# Altium schematic sheet Sheet1.SchDoc
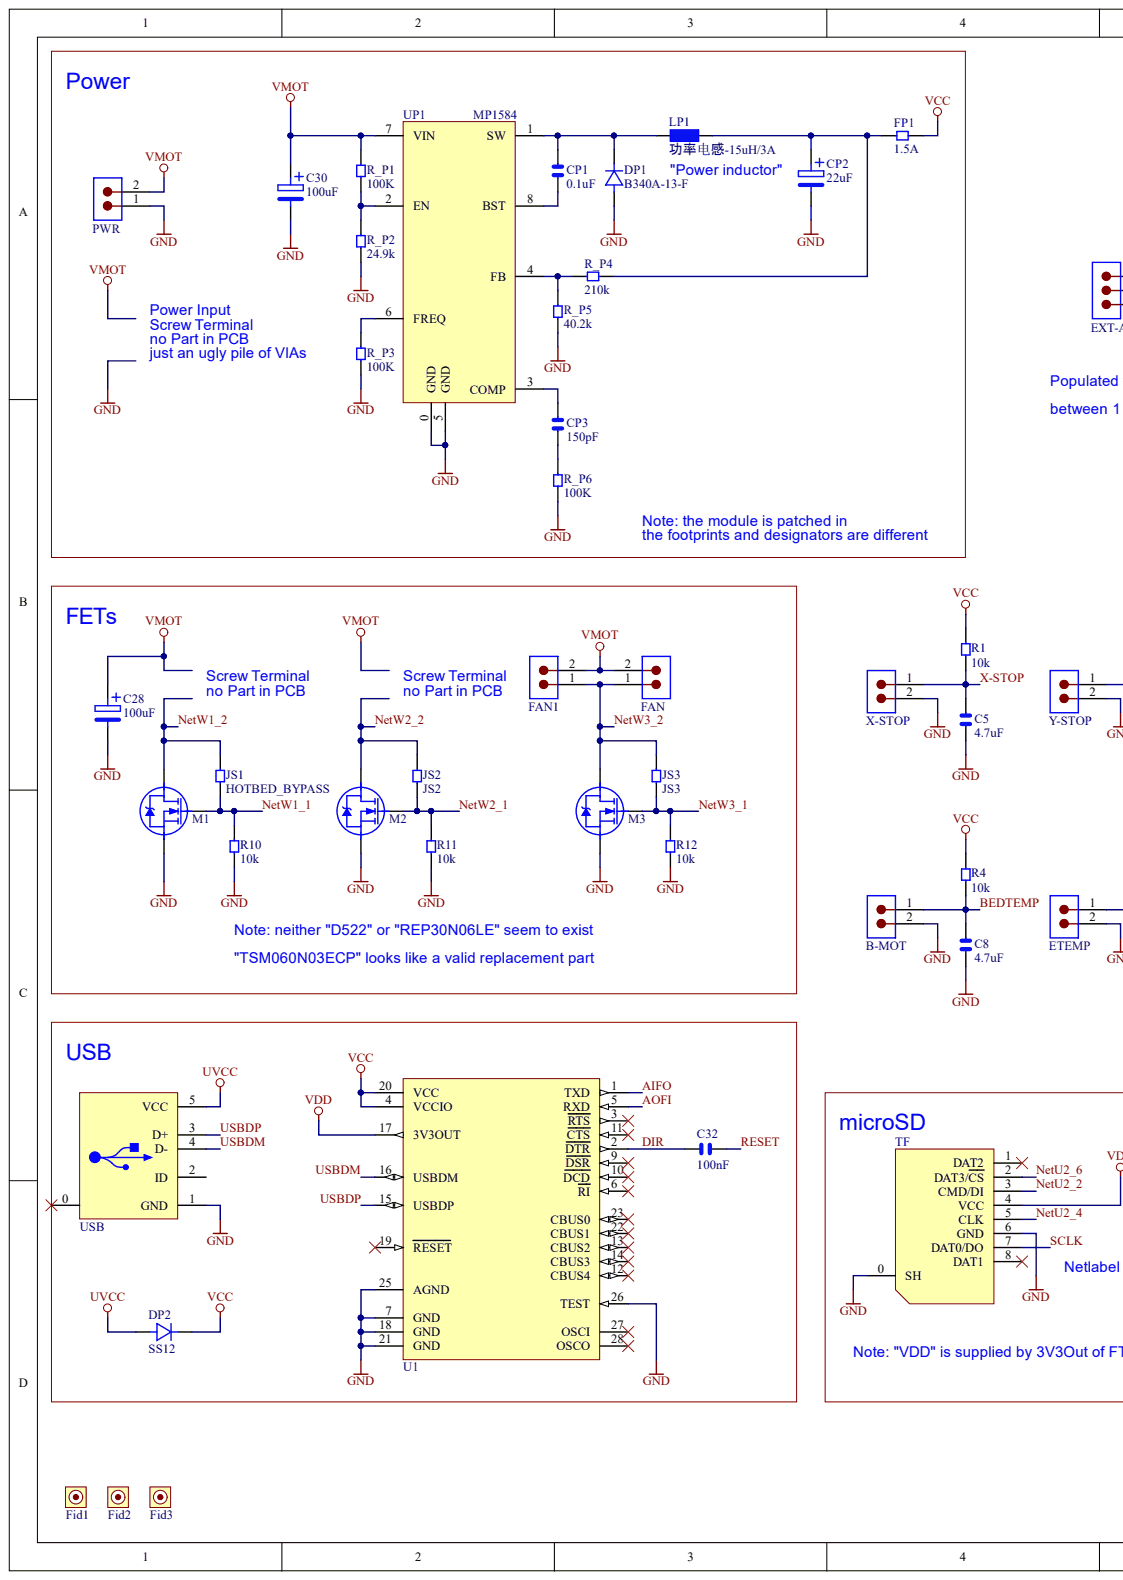
[diagram p0: Sheet1.SchDoc, left]
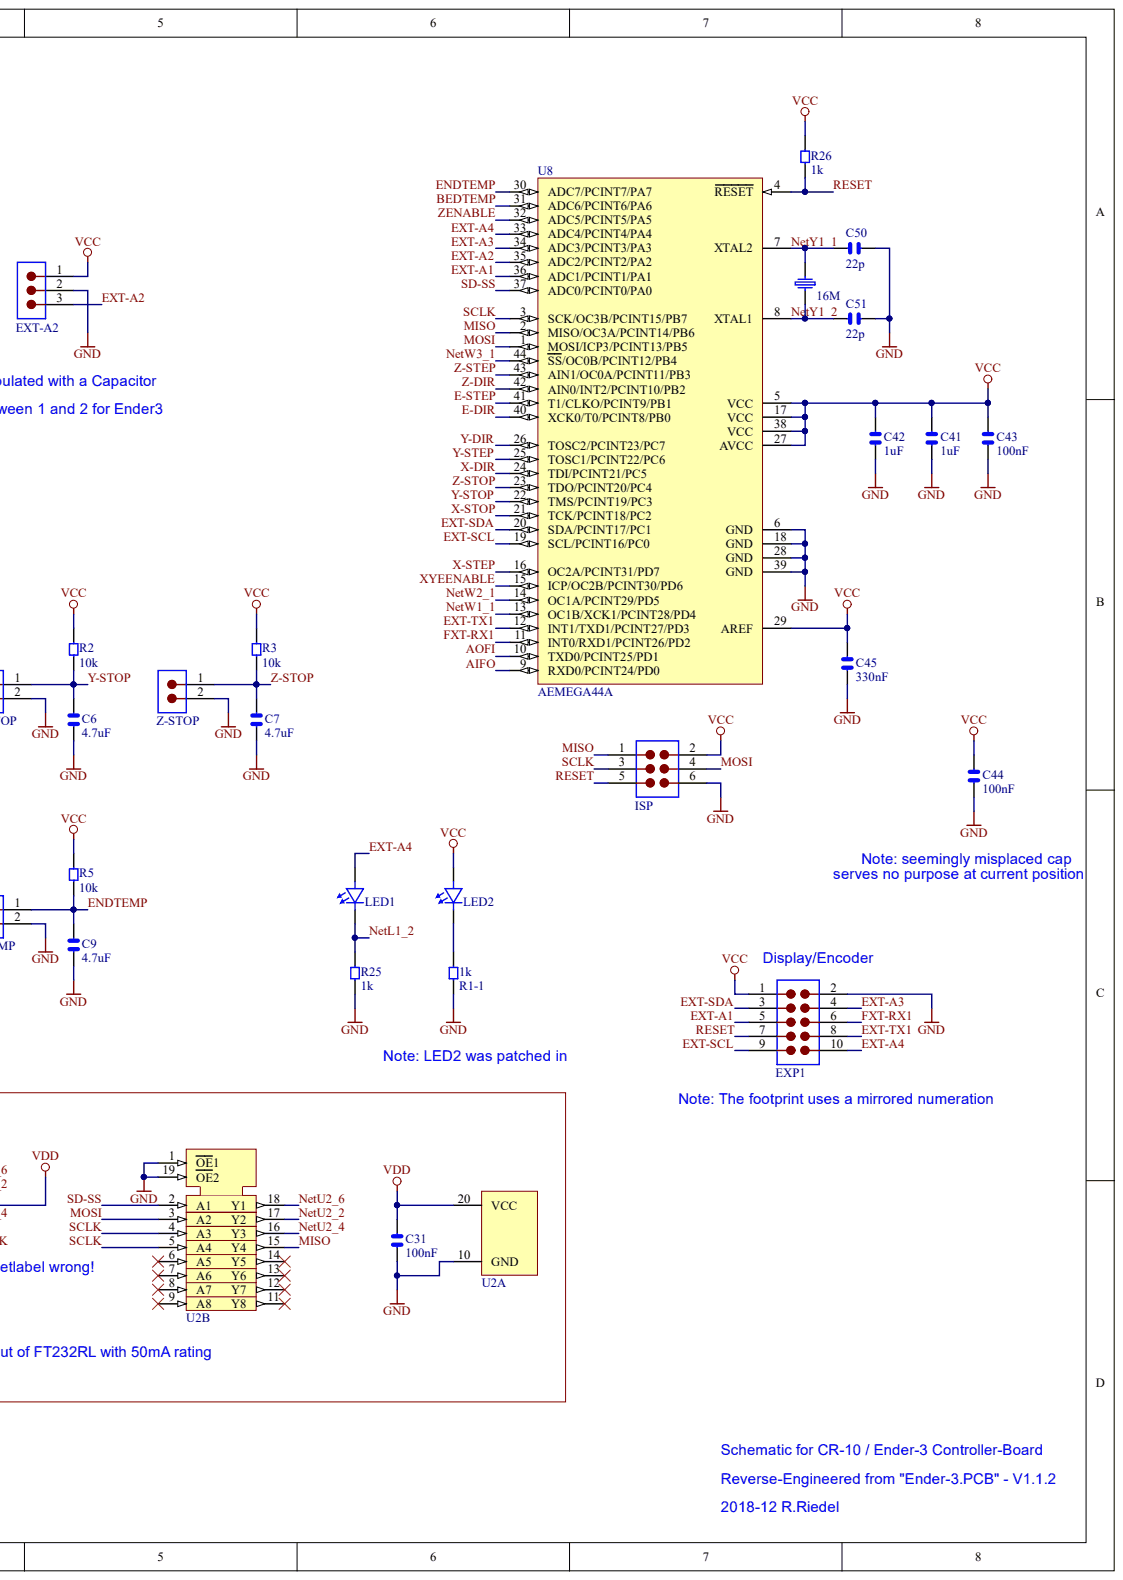
[diagram p1: Sheet1.SchDoc, right]

## Parts
1k  R1-1  [0603]
16M  16Mhz  [CRY]  (value not drawn)
B-MOT  Header 1x2  [P2]  (value not drawn)
C5  4.7uF  [0805]
C6  4.7uF  [0805]
C7  4.7uF  [0805]
C8  4.7uF  [0805]
C9  4.7uF  [0805]
C28  100uF  [CAP-100]
C30  100uF  [CAP-100]
C31  100nF  [0805]
C32  100nF  [0603]
C41  1uF  [0603]
C42  1uF  [0603]
C43  100nF  [0603]
C44  100nF  [0603]
C45  330nF  [0603]
C50  22p  [0603]
C51  22p  [0603]
CP1  0.1uF  [C0603]
CP2  22uF  [RVT4X5.5]
CP3  150pF  [C0603]
DP1  B340A-13-F  [D-SMA]
DP2  SS12  [D-SMA]
ETEMP  Header 1x2  [P2]  (value not drawn)
EXP1  Header 2x5  [SOP10]  (value not drawn)
EXT-A2  XH-3A  [XH-3A]  (value not drawn)
FAN  Header 1x2  [P2]  (value not drawn)
FAN1  Header 1x2  [P2]  (value not drawn)
Fid1  Fiducial  [Fiducial-A]  (value not drawn)
Fid2  Fiducial  [Fiducial-A]  (value not drawn)
Fid3  Fiducial  [Fiducial-A]  (value not drawn)
FP1  1.5A  [MF-MSMF050]
ISP  HEADER 3X2  (value not drawn)
JS1  HOTBED_BYPASS  [R10]
JS2  JS2  [R10]
JS3  JS3  [R10]
LED1  DIODE  [0603]  (value not drawn)
LED2  RLED  [0603]  (value not drawn)
LP1  功率电感-15uH/3A  [DO3316P]
M1  REP30N06LE  [TO-252]
M2  REP30N06LE  [TO-252]
M3  REP30N06LE  [TO-252]
PWR  JP5  [MOT-2]  (value not drawn)
R1  10k  [0603]
R2  10k  [0603]
R3  10k  [0603]
R4  10k  [0603]
R5  10k  [0603]
R10  10k  [0603]
R11  10k  [0603]
R12  10k  [0603]
R25  1k  [0603]
R26  1k  [0603]
R_P1  100K  [R0603]
R_P2  24.9k  [R0603]
R_P3  100K  [R0603]
R_P4  210k  [R0603]
R_P5  40.2k  [R0603]
R_P6  100K  [R0603]
TF  TF  [TF]
U1  FT232RL  [PL2303]  (value not drawn)
U2  HC541  [SSOP-20]  (value not drawn)  (parts A,B)
U8  AEMEGA44A  [QFP-44]
UP1  MP1584  [SOIC8E]
USB  HEADER 5  [USB]  (value not drawn)
X-STOP  Header 1x2  [P2]  (value not drawn)
Y-STOP  Header 1x2  [P2]  (value not drawn)
Z-STOP  Header 1x2  [P2]  (value not drawn)

## Nets
GND: 1k.2 B-MOT.2 C5.2 C6.2 C7.2 C8.2 C9.2 C28.2 C30.2 C31.2 C41.2 C42.2 C43.2 C44.2 C45.2 C50.2 C51.2 CP2.2 DP1.1 ETEMP.2 EXP1.2 EXT-A2.2 ISP.6 M1.3 M2.3 M3.3 PWR.1 R10.2 R11.2 R12.2 R25.2 R_P2.2 R_P3.2 R_P5.2 R_P6.2 TF.0(SH) TF.6(GND) U1.7(GND) U1.18(GND) U1.21(GND) U1.25(AGND) U1.26(TEST) U2.1(OE1) U2.10(GND) U2.19(OE2) U8.6(GND) U8.18(GND) U8.28(GND) U8.39(GND) UP1.0(GND) UP1.5(GND) USB.1(GND) X-STOP.2 Y-STOP.2 Z-STOP.2
UVCC: DP2.1 USB.5(VCC)
VCC: C41.1 C42.1 C43.1 C44.1 C45.1 DP2.2 EXP1.1 EXT-A2.1 FP1.2 ISP.2 LED2.2 R1.2 R2.2 R3.2 R4.2 R5.2 R26.2 U1.4(VCCIO) U1.20(VCC) U8.5(VCC) U8.17(VCC) U8.27(AVCC) U8.29(AREF) U8.38(VCC)
VDD: C31.1 TF.4(VCC) U1.17(3V3OUT) U2.20(VCC)
VMOT: C28.1 C30.1 FAN.2 FAN1.2 PWR.2 R_P1.1 UP1.7(VIN)
AIFO: U1.1(TXD) U8.9(RXD0/PCINT24/PD0)
AOFI: U1.5(RXD) U8.10(TXD0/PCINT25/PD1)
BEDTEMP: B-MOT.1 C8.1 R4.1 U8.31(ADC6/PCINT6/PA6)
DIR: C32.1 U1.2(DTR)
E-DIR: U8.40(XCK0/T0/PCINT8/PB0)
E-STEP: U8.41(T1/CLKO/PCINT9/PB1)
ENDTEMP: C9.1 ETEMP.1 R5.1 U8.30(ADC7/PCINT7/PA7)
EXT-A1: EXP1.5 U8.36(ADC1/PCINT1/PA1)
EXT-A2: EXT-A2.3 U8.35(ADC2/PCINT2/PA2)
EXT-A3: EXP1.4 U8.34(ADC3/PCINT3/PA3)
EXT-A4: EXP1.10 LED1.1 U8.33(ADC4/PCINT4/PA4)
EXT-SCL: EXP1.9 U8.19(SCL/PCINT16/PC0)
EXT-SDA: EXP1.3 U8.20(SDA/PCINT17/PC1)
EXT-TX1: EXP1.8 U8.12(INT1/TXD1/PCINT27/PD3)
FXT-RX1: EXP1.6 U8.11(INT0/RXD1/PCINT26/PD2)
MISO: ISP.1 U2.15(Y4) U8.2(MISO/OC3A/PCINT14/PB6)
MOSI: ISP.4 U2.3(A2) U8.1(MOSI/ICP3/PCINT13/PB5)
NETL1_2: LED1.2 R25.1
NETU2_2: TF.3(CMD/DI) U2.17(Y2)
NETU2_4: TF.5(CLK) U2.16(Y3)
NETU2_6: TF.2(DAT3/CS) U2.6(A5) U2.18(Y1)
NETW1_1: JS1.1 M1.1 R10.1 U8.13(OC1B/XCK1/PCINT28/PD4)
NETW1_2: JS1.2 M1.2
NETW2_1: JS2.1 M2.1 R11.1 U8.14(OC1A/PCINT29/PD5)
NETW2_2: JS2.2 M2.2
NETW3_1: JS3.1 M3.1 R12.1 U8.44(SS/OC0B/PCINT12/PB4)
NETW3_2: FAN.1 FAN1.1 JS3.2 M3.2
NETY1_1: 16M.1 C50.1 U8.7(XTAL2)
NETY1_2: 16M.2 C51.1 U8.8(XTAL1)
RESET: C32.2 EXP1.7 ISP.5 R26.1 U8.4(RESET)
SCLK: ISP.3 TF.7(DAT0/DO) U2.4(A3) U2.5(A4) U8.3(SCK/OC3B/PCINT15/PB7)
SD-SS: U2.2(A1) U8.37(ADC0/PCINT0/PA0)
USBDM: U1.16(USBDM) USB.4(D-)
USBDP: U1.15(USBDP) USB.3(D+)
X-DIR: U8.24(TDI/PCINT21/PC5)
X-STEP: U8.16(OC2A/PCINT31/PD7)
X-STOP: C5.1 R1.1 U8.21(TCK/PCINT18/PC2) X-STOP.1
XYEENABLE: U8.15(ICP/OC2B/PCINT30/PD6)
Y-DIR: U8.26(TOSC2/PCINT23/PC7)
Y-STEP: U8.25(TOSC1/PCINT22/PC6)
Y-STOP: C6.1 R2.1 U8.22(TMS/PCINT19/PC3) Y-STOP.1
Z-DIR: U8.42(AIN0/INT2/PCINT10/PB2)
Z-STEP: U8.43(AIN1/OC0A/PCINT11/PB3)
Z-STOP: C7.1 R3.1 U8.23(TDO/PCINT20/PC4) Z-STOP.1
ZENABLE: U8.32(ADC5/PCINT5/PA5)
Net1k_1: 1k.1 LED2.1
NetCP1_1: CP1.1 UP1.8(BST)
NetCP1_2: CP1.2 DP1.2 LP1.2 UP1.1(SW)
NetCP2_1: CP2.1 FP1.1 LP1.1 R_P4.2
NetCP3_1: CP3.1 R_P6.1
NetCP3_2: CP3.2 UP1.3(COMP)
NetR_P1_2: R_P1.2 R_P2.1 UP1.2(EN)
NetR_P3_1: R_P3.1 UP1.6(FREQ)
NetR_P4_1: R_P4.1 R_P5.1 UP1.4(FB)

Not connected: TF 1(DAT2) 8(DAT1); U1 3(RTS) 6(RI) 9(DSR) 10(DCD) 11(CTS) 12(CBUS4) 13(CBUS2) 14(CBUS3) 19(RESET) 22(CBUS1) 23(CBUS0) 27(OSCI) 28(OSCO); U2 7(A6) 8(A7) 9(A8) 11(Y8) 12(Y7) 13(Y6) 14(Y5); USB 0 2(ID)

## Library data of the parts
1k JS1-JS3 R10-R12 R25 R26 R_P1 R_P3-R_P6 = Resistor: 0603 Chip Resistor; Component Kind: Standard; Library Name: Ender3.SCHLIB
16M = Crystal: Component Kind: Standard; Description: Crystal; Library Name: Ender3.SCHLIB
C5-C9 = CAP_2_V2: 0805 Chip Capacitor; Component Kind: Standard; Library Name: Ender3.SCHLIB
C28 C30 CP2 = Capacitor Pol: Capacitor Polarized; Component Kind: Standard; Library Name: Ender3.SCHLIB
C31 C32 C41-C45 C50 C51 = Capacitor: 0805 Chip Capacitor; Component Kind: Standard; Library Name: Ender3.SCHLIB
CP1 CP3 = Capacitor: Chip Capacitor; Component Kind: Standard; Library Name: Ender3.SCHLIB
DP1 DP2 = Diode_A1_K2: Diode; Component Kind: Standard; Library Name: Ender3.SCHLIB
EXT-A2 = Header 1x3: Component Kind: Standard; Description: Header 1x3; Library Name: Ender3.SCHLIB
FP1 R_P2 = Resistor: Chip Resistor; Component Kind: Standard; Library Name: Ender3.SCHLIB
ISP = Header 2x3: Component Kind: Standard; Description: Header 2x3; Library Name: Ender3.SCHLIB
LED1 = LED_A1C2: LED; Component Kind: Standard; Library Name: Ender3.SCHLIB
LED2 = LED_C1A2: LED; Component Kind: Standard; Library Name: Ender3.SCHLIB
LP1 = Inductor: Component Kind: Standard; Description: Inductor; Library Name: Ender3.SCHLIB
M1-M3 = N-FET: Component Kind: Standard; Description: N-FET; Library Name: Ender3.SCHLIB
PWR = Header 1x2: Component Kind: Standard; Description: Header 1x2; Library Name: Ender3.SCHLIB
R1-R5 = RES_2_V2: 0603 Chip Resistor; Component Kind: Standard; Library Name: Ender3.SCHLIB
TF = microSD: Component Kind: Standard; Description: microSD; Library Name: Ender3.SCHLIB
U1 = FT232RL: FTDI USB UART I.C.; Component Kind: Standard; Library Name: Ender3.SCHLIB
U2 = 74HC541: 74HC541 - Octal buffer/line driver; Component Kind: Standard; Library Name: Ender3.SCHLIB
U8 = ATmegaxx4PA-xx: AVR 8-Bit mikrocontroller, 128k FLASH, TQFP44, -40°C to +85°C; Part Number: ATmega1284P-AU; Component Kind: Standard; PackageDescription: TQFP44; Library Name: Ender3.SCHLIB
USB = USB: Component Kind: Standard; Description: USB; Library Name: Ender3.SCHLIB
B-MOT ETEMP FAN FAN1 X-STOP Y-STOP Z-STOP = Header 1x2: Component Kind: Standard; Description: Header 1x2; Library Name: Ender3.SCHLIB
EXP1 = Header 2x5: Component Kind: Standard; Description: Header 2x5; Library Name: Ender3.SCHLIB
Fid1-Fid3 = Fiducial: Component Kind: Standard; Description: Fiducial; Library Name: Ender3.SCHLIB
UP1 = MP1584: Component Kind: Standard; Description: MP1584; Library Name: Ender3.SCHLIB
